# Altium schematic sheet Scoop.SchDoc(Scoop)
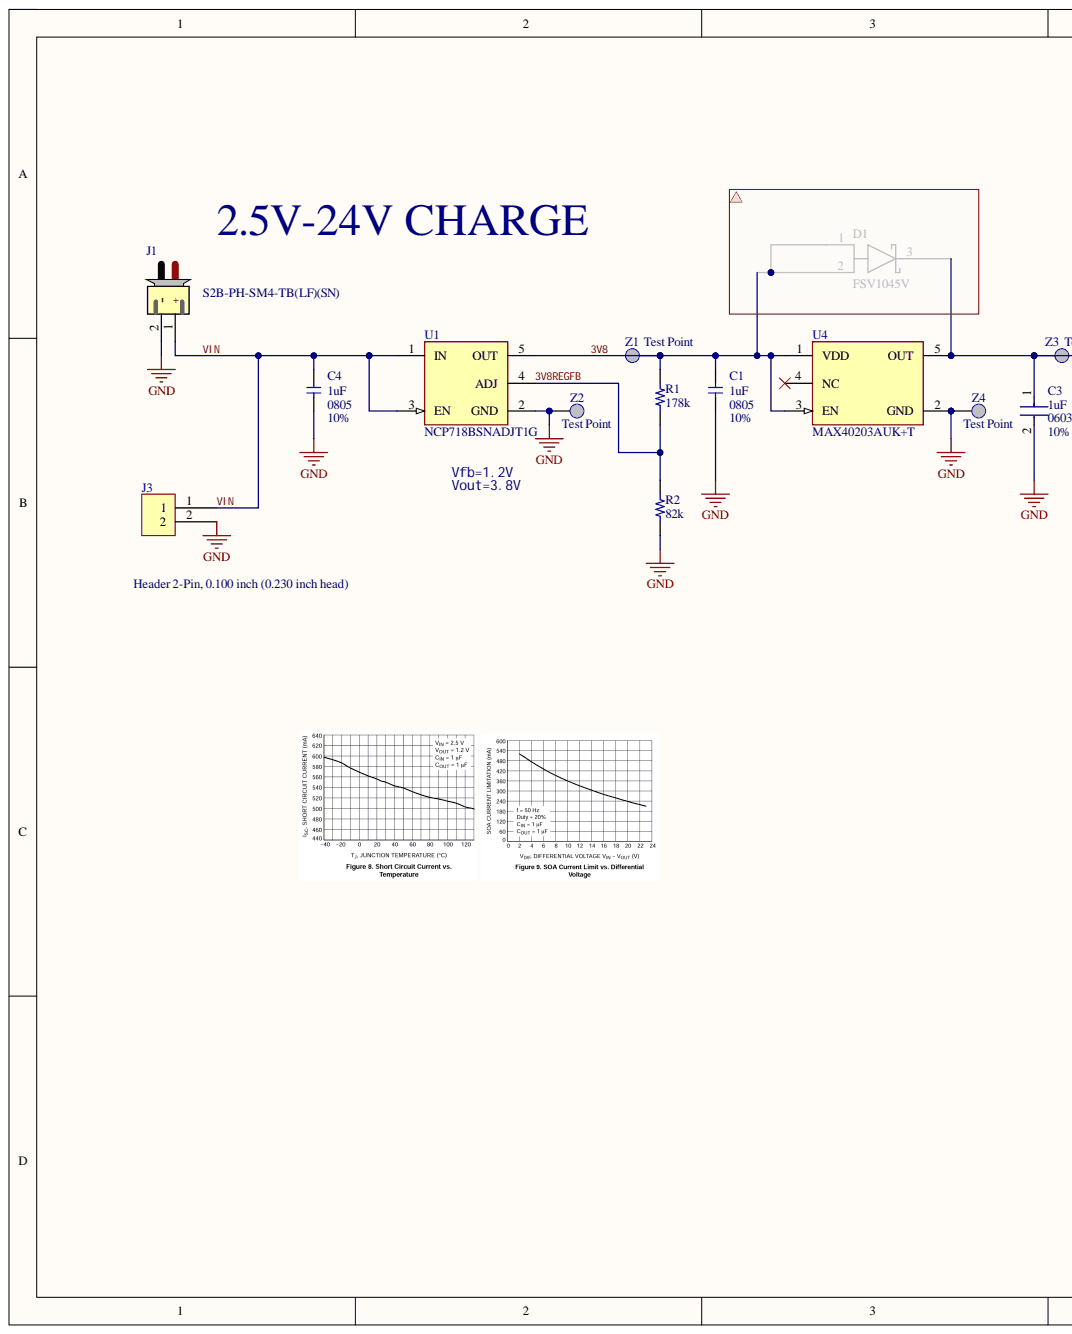
[diagram p0: Scoop.SchDoc, left]
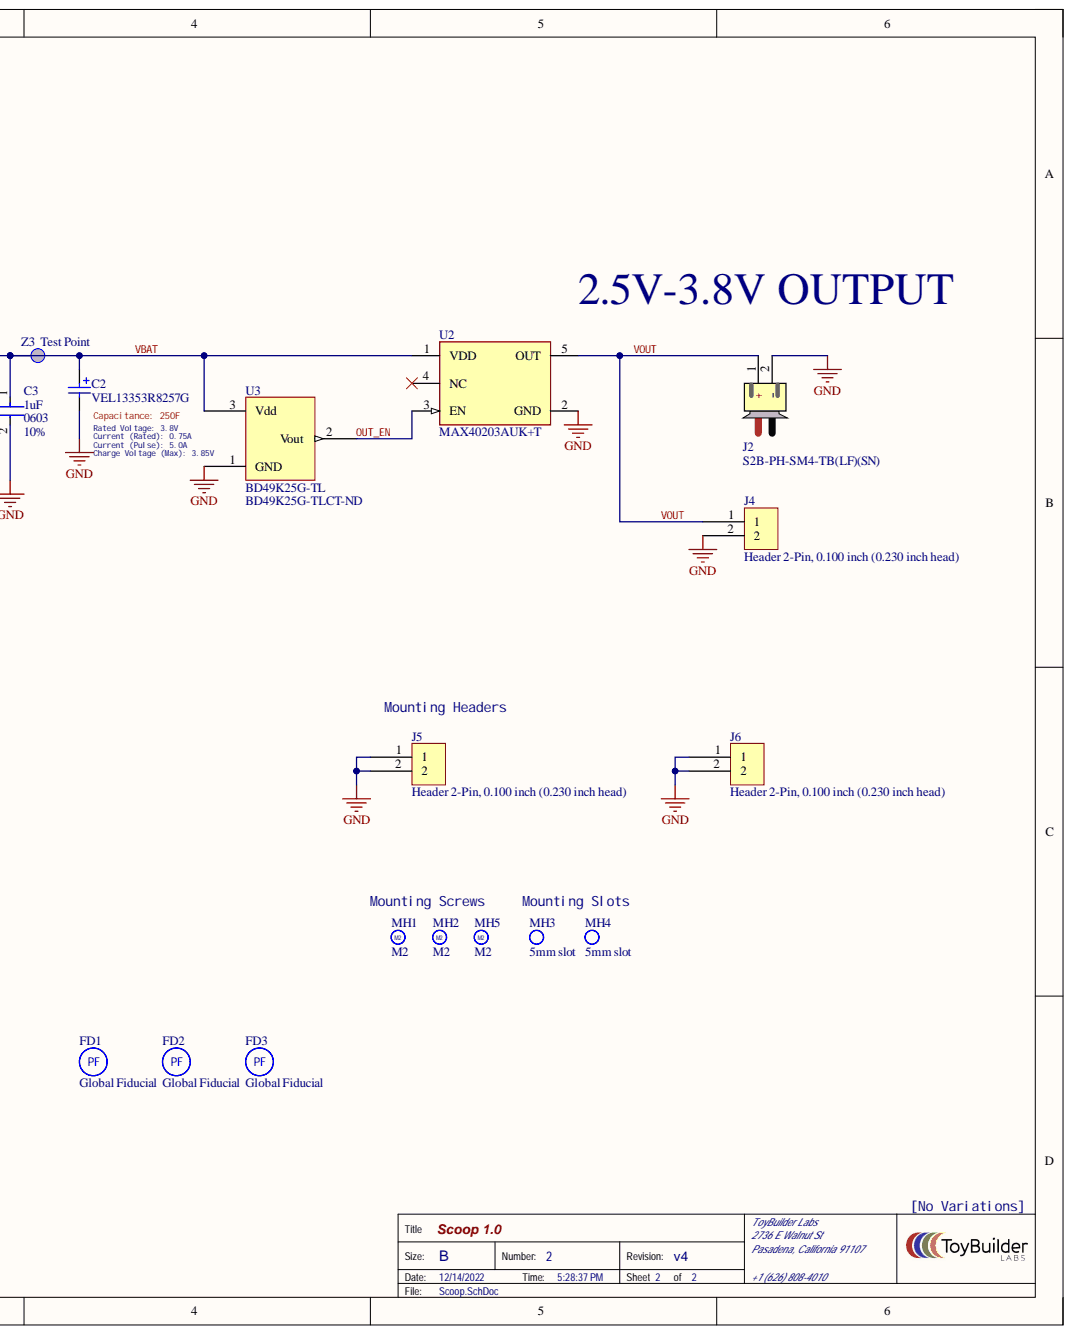
[diagram p1: Scoop.SchDoc, right]

## Parts
C1  Capacitance: 1uF  Case Code (Imperial): 0805  Tolerance: 10%  [CAP FILM 0805]  (hidden comment: CL21B105KBFNNNG)
C2  VEL13353R8257G  [VINA_VPC1335_RRA]
C3  Capacitance: 1uF  Case Code (Imperial): 0603  Tolerance: 10%  [FP-0603-L_1_6_0_15-W_0_81-IPC_C]  (hidden comment: 0603YC105KAT2A)
C4  Capacitance: 1uF  Case Code (Imperial): 0805  Tolerance: 10%  [CAP FILM 0805]  (hidden comment: CL21B105KBFNNNG)
FD1  Global Fiducial  [FID_1mm_3mm]  (no BOM)
FD2  Global Fiducial  [FID_1mm_3mm]  (no BOM)
FD3  Global Fiducial  [FID_1mm_3mm]  (no BOM)
J1  S2B-PH-SM4-TB(LF)(SN)  [BAT-AF-S2B-PH-SM4]
J2  S2B-PH-SM4-TB(LF)(SN)  [BAT-AF-S2B-PH-SM4]
J3  Header 2-Pin, 0.100 inch (0.230 inch head)  [HEADER_AA_002_NO_SILK]  (no BOM)
J4  Header 2-Pin, 0.100 inch (0.230 inch head)  [HEADER_AA_002_NO_SILK]  (no BOM)
J5  Header 2-Pin, 0.100 inch (0.230 inch head)  [HEADER_AA_002_NO_SILK]  (no BOM)
J6  Header 2-Pin, 0.100 inch (0.230 inch head)  [HEADER_AA_002_NO_SILK]  (no BOM)
MH1  M2  [HOLE_2MM]  (no BOM)
MH2  M2  [HOLE_2MM]  (no BOM)
MH3  5mm slot  [MHSLOT_2_5MM_5MM_WIDTH]  (no BOM)
MH4  5mm slot  [MHSLOT_2_5MM_5MM_WIDTH]  (no BOM)
MH5  M2  [HOLE_2MM]  (no BOM)
R1  178k  [RES 0805_2012]
R2  82k  [RES 0805_2012]
U1  NCP718BSNADJT1G  [FP-419AE-01-IPC_C]
U2  MAX40203AUK+T  [FP-U5-2-MFG]
U3  BD49K25G-TL  Supplier Part Number 1: BD49K25G-TLCT-ND  [ROHM_SSOP3_N]
U4  MAX40203AUK+T  [FP-U5-2-MFG]
Z1  Test Point  [testp_40pad]  (no BOM)
Z2  Test Point  [testp_40pad]  (no BOM)
Z3  Test Point  [testp_40pad]  (no BOM)
Z4  Test Point  [testp_40pad]  (no BOM)

## Nets
GND: C1.2 C2.2 C3.2 C4.2 J1.2 J2.2 J3.2 J4.2 J5.1 J5.2 J6.1 J6.2 R2.1 U1.2(GND) U2.2(GND) U3.1 U4.2(GND) Z2.1 Z4.1
3V8: C1.1 R1.2 U1.5(OUT) U4.1(VDD) U4.3(EN) Z1.1
3V8REGFB: R1.1 R2.2 U1.4(ADJ)
OUT_EN: U2.3(EN) U3.2
VBAT: C2.1 C3.1 U2.1(VDD) U3.3 U4.5(OUT) Z3.1
VIN: C4.1 J1.1 J3.1 U1.1(IN) U1.3(EN)
VOUT: J2.1 J4.1 U2.5(OUT)

Not connected: U2 4(NC); U4 4(NC)

## Library data of the parts
C1 C4 = CL21B105KBFNNNG: Cap Ceramic 1uF 50V X7R 10% SMD 0805 125°C Embossed Plastic T/R; Manufacturer: Samsung; Case Code (Metric): 2012; Case/Package: 0805; Category: Ceramic Capacitors; Component Kind: Standard; ComponentLink1Description: https://datasheet.ciiva.com/25733/cl21b105kbfnnng-25733278.pdf?src-supplier=Digi-Key; ComponentLink1URL: https://datasheet.ciiva.com/25733/cl21b105kbfnnng-25733278.pdf?src-supplier=Digi-Key; ComponentLink2Description: https://datasheet.ciiva.com/29199/455898-da-01-en-vs-kondensator-0805-29199201.pdf?src-supplier=Conrad; ComponentLink2URL: https://datasheet.ciiva.com/29199/455898-da-01-en-vs-kondensator-0805-29199201.pdf?src-supplier=Conrad; ComponentLink3Description: https://datasheet.ciiva.com/26310/mlcc-catalogdownload2013-eng-26310125.pdf?src-supplier=Distrelec; ComponentLink3URL: https://datasheet.ciiva.com/26310/mlcc-catalogdownload2013-eng-26310125.pdf?src-supplier=Distrelec; ComponentLink4Description: https://datasheet.ciiva.com/16428/cl05b104kq5nnnc-16428872.pdf?src-supplier=Future+Electronics; ComponentLink4URL: https://datasheet.ciiva.com/16428/cl05b104kq5nnnc-16428872.pdf?src-supplier=Future+Electronics; Construction: Flat; Contact Plating: Tin; Depth: 1.25mm; Dielectric: X7R; Length: 2mm; Manufacturer Part Number: CL21B105KBFNNNG; Material: Ceramic; Max Operating Temperature: 125°C; Min Operating Temperature: -55°C; Mount: Surface Mount; Package Quantity: 3000; Packaging: Tape and Reel; Pricing 1: 3000=0.01061, 6000=0.01046, 12000=0.01016, 18000=0.00993, 24000=0.00955 (USD); RoHS: Yes; RoHS Compliant: Yes; Series: CL; Stock 1: 6000; Supplier 1: Avnet; Supplier Part Number 1: CL21B105KBFNNNG; Termination: Wraparound; Thickness: 0.053inch; Voltage: 50V; Voltage Rating: 50V; Width: 0.049inch; Library Name: scoop.SCHLIB
C2 = VINA_VEL13353R8257G: Hybrid Polarized Capacitor/Battery (Radial) VEL13353R8257G; Manufacturer: VINA Tech; Capacitance: 250F; Charge Current (Max): 3.0A; Charge Voltage (Max): 3.85V; Current (Pulse): 5.0A; Current (Rated): 0.75A; Operating Voltage: 2.5V - 3.8V; Rated Voltage: 3.8V; Surge Voltage: 4.0V; Component Kind: Standard; Library Name: TBL Battery.SchLib; Manufacturer Part Number: VEL13353R8257G; Operating Temperature: -25C - +70C; Signal Integrity: Cap Pol
C3 = CMP-2006-04499-2: General Purpose Ceramic Capacitor, 0603, 1uF, 10%, X7R, 16V; Dissipation Factor: 3.5%; Insulation Resistance: 100GR; Case Code (Metric): 1608; Case/Package: 0603; Component Kind: Standard; Construction: Flat; Contact Plating: Tin; Depth: 0.81mm; Dielectric: X7R; Dielectric Material: Ceramic; Height: 0.94mm; Lead Free: Lead Free; Length: 1.6mm; Library Name: Altium Content Vault; Max Operating Temperature: 125°C; Min Operating Temperature: -55°C; Mount: Surface Mount; Package Quantity: 1; Packaging: Tape and Reel; Radiation Hardening: No; REACH SVHC: No SVHC; RoHS Compliant: Yes; Series: -; Termination: SMD/SMT; Thickness: 0.035inch; Voltage: 16V; Voltage Rating: 16V; Voltage Rating (DC): 16V; Width: 0.032inch
FD1-FD3 = Fiducial_Global: Fiducial, Global; Library Name: TBL Common.SchLib
J1 J2 = BAT-PH-ADAFRUIT-SMT-RA: Battery Connector PH-2POS wired for Adafruit style packs.  SMD RA JST S2B-PH-SM4-TB(LF)(SN); Manufacturer: JST (JAPAN SOLDERLESS TERMINALS); Manufacturer 1: 4UCON; Manufacturer Part Number 1: 20404; Component Kind: Standard; ComponentLink1Description: http://www.farnell.com/datasheets/1699381.pdf; ComponentLink1URL: http://www.farnell.com/datasheets/1699381.pdf; Manufacturer Part Number: S2B-PH-SM4-TB(LF)(SN); Mounting Type: SMD; Library Name: scoop.SCHLIB
J3-J6 = HEADER_AA_2: Header 2-Pin, 0.100 in; Function 1: Function 1; Function 2: Function 2; Library Name: scoop.SCHLIB
MH1 MH2 MH5 = Bare Hole M2: Bare Hole, M2; Library Name: scoop.SCHLIB
MH3 MH4 = MH_SLOT_2_5MM_5MM: Library Name: Blues Wireless.SchLib
R1 = RC0805FR-07178KL: RES 178K OHM 1% 1/8W 0805; Manufacturer: Yageo; Database Table Name: Resistors - Surface Mount - 0805; Power (Watts): 0.125W, 1/8W; Resistance: 178 kOhms; Temperature Coefficient: ±100ppm/°C; Component Kind: Standard; ComponentLink1Description: Datasheet; ComponentLink1URL: https://www.yageo.com/upload/media/product/productsearch/datasheet/rchip/PYu-RC_Group_51_RoHS_L_10.pdf; ComponentLink2Description: DigiKey Link; ComponentLink2URL: https://www.digikey.com/en/products/detail/yageo/RC0805FR-07178KL/727642; Composition: Thick Film; Device Package: 0805 (2012 Metric); Features: Moisture Resistant; Height - Seated (Max): 0.024" (0.60mm); LastUpdated: 9/19/2022 1:36:16 PM; Library Name: Celestial Altium Library - altium_library.DbLib; Lifecycle Status: Active; Minimum Order: 1; Number of Terminations: 2; Operating Temperature: -55°C ~ 155°C; Package / Case: 0805 (2012 Metric); Packaging: TapeAndReel; Part Number: RC0805FR-07178KL; Part Status: Active; PartId: 39696; Price: 0.01; Series: RC_L; Size / Dimension: 0.079" L x 0.049" W (2.00mm x 1.25mm); Supplier 1: DigiKey; Supplier Device Package: 0805; Supplier Part Number 1: 311-178KCRCT-ND; Tolerance: ±1%; Value: 178k
R2 = RC0805FR-0782KL: RES 82K OHM 1% 1/8W 0805; Manufacturer: Yageo; Database Table Name: Resistors - Surface Mount - 0805; Power (Watts): 0.125W, 1/8W; Resistance: 82 kOhms; Temperature Coefficient: ±100ppm/°C; Component Kind: Standard; ComponentLink1Description: Datasheet; ComponentLink1URL: https://www.yageo.com/upload/media/product/productsearch/datasheet/rchip/PYu-RC_Group_51_RoHS_L_10.pdf; ComponentLink2Description: DigiKey Link; ComponentLink2URL: https://www.digikey.com/en/products/detail/yageo/RC0805FR-0782KL/728165; Composition: Thick Film; Device Package: 0805 (2012 Metric); Features: Moisture Resistant; Height - Seated (Max): 0.024" (0.60mm); LastUpdated: 9/19/2022 1:36:16 PM; Library Name: Celestial Altium Library - altium_library.DbLib; Lifecycle Status: Active; Minimum Order: 1; Number of Terminations: 2; Operating Temperature: -55°C ~ 155°C; Package / Case: 0805 (2012 Metric); Packaging: TapeAndReel; Part Number: RC0805FR-0782KL; Part Status: Active; PartId: 39688; Price: 0.01; Series: RC_L; Size / Dimension: 0.079" L x 0.049" W (2.00mm x 1.25mm); Supplier 1: DigiKey; Supplier Device Package: 0805; Supplier Part Number 1: 311-82.0KCRCT-ND; Tolerance: ±1%; Value: 82k
U1 = CMP-07128-000004-1: 300 MA LOW IQ WIDE INPUT; Manufacturer: On Semiconductor; Accuracy Percentage: 2%; Dropout Voltage: 480mV; Max Junction Temp: +125°C; Max Supply Voltage: 24V; Min Supply Voltage: 2.5V; Nominal Supply Current: 50-300uA; Number Of Outputs: 1; Output Current: 300mA; Output Type: AdjustableProgrammable; Output Voltage: 1.2-5V; Standoff Height: 0.01mm; Automotive: No; Category: IC; Component Kind: Standard; ComponentLink2Description: Datasheet; ComponentLink2URL: https://www.onsemi.com/pub/Collateral/NCP718-D.PDF; Device Class L1: Integrated Circuits (ICs); Device Class L2: Power Management ICs; Device Class L3: Voltage Regulators - Linear; Digikey Description: 300 MA LOW IQ WIDE INPUT; Height: 1mm; Lead Free: Yes; Library Name: Altium Content Vault; Manufacturer Part Number: NCP718BSNADJT1G; Mouser Description: LDO Regulator, 300 mA, Wide Vin, Ultra-Low Iq Adjustable, Non-Active Discharge, TSOT23-5; Octopart: https://octopart.com/ncp718bsnadjt1g-on+semiconductor-87658781; Package: 419AE-01; RoHS: TRUE; Temperature: to +125°C; Temperature Range High: +125°C
U2 U4 = CMP-05714-000007-1: IC IDEAL DIODE; Component Kind: Standard; Library Name: Altium Content Vault
U3 = ROHM_BD49K25G-TL: Voltage Supervisor, 2.50V (Pin 1 GND) Push-Pull SSOP3 (SOT-23); Manufacturer: Rohm; Accuracy: 1%; Max Power Dissipation: 700mW; Max Reset Threshold Voltage: 2.525V; Max Supply Voltage: 10V; Min Reset Threshold Voltage: 2.475V; Min Supply Voltage: 1.2V; Operating Supply Current: 900nA; Operating Supply Voltage: 6V; Output: TOTEM-POLE; Reset: Active Low; Threshold Voltage: 2.5V; Watchdog Timer: No; Case/Package: SSOP; Category: Voltage Supervisors; Component Kind: Standard; ComponentLink1Description: https://datasheet.ciiva.com/15105/getdatasheetpartid-705740-15105788.pdf?src-supplier=Verical; ComponentLink1URL: https://datasheet.ciiva.com/15105/getdatasheetpartid-705740-15105788.pdf?src-supplier=Verical; Lead Free: Lead Free; Library Name: TBL PMIC.SchLib; Manufacturer Part Number: BD49K25G-TL; Max Operating Temperature: 105°C; Min Operating Temperature: -40°C; Mount: Surface Mount; RoHS: Yes; Supplier 1: Digi-Key; Type: Simple Reset/Power-On Reset,Voltage Detector
Z1-Z4 = test_pt: Description: Test point; Library Name: TBL Common.SchLib
